# Altium schematic sheet [1] Peripherals.SchDoc("[1] Peripherals")
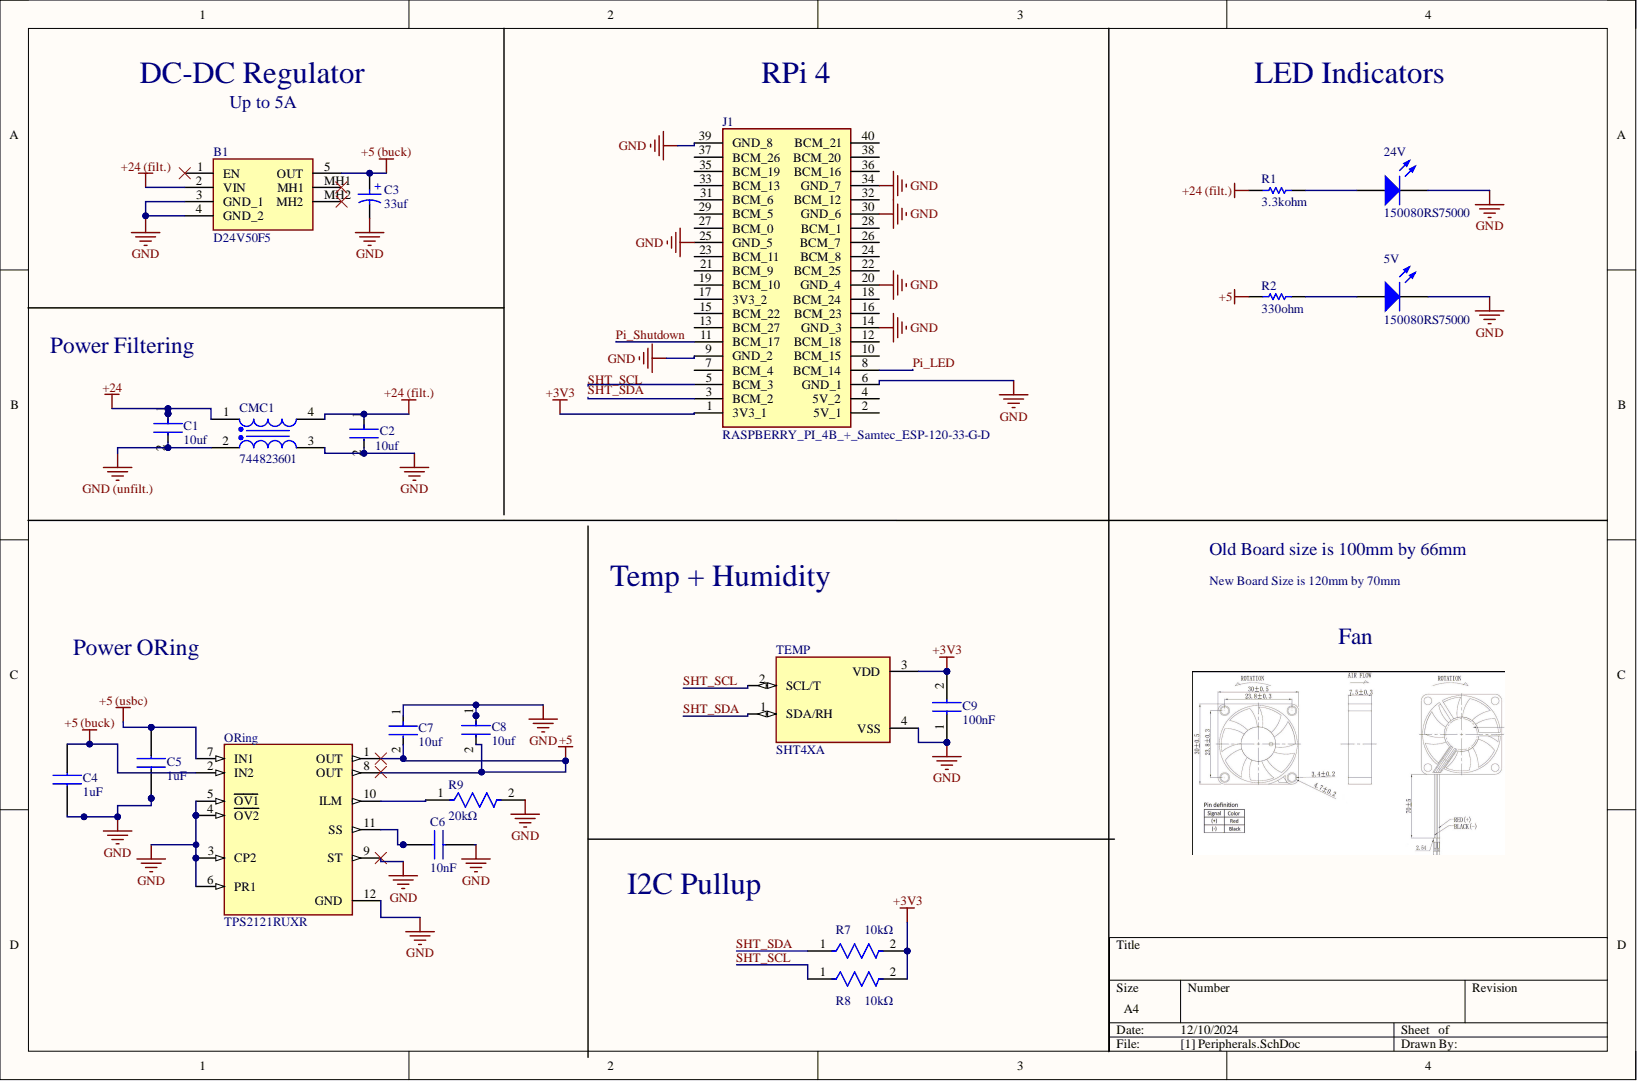
[diagram p0: [1] Peripherals.SchDoc]

## Parts
5V  150080RS75000
24V  150080RS75000
B1  D24V50F5
C1  10uf
C2  10uf
C3  33uf
C4  1uF
C5  1uF
C6  10nF
C7  10uf
C8  10uf
C9  100nF
CMC1  744823601
J1  RASPBERRY_PI_4B_+_Samtec_ESP-120-33-G-D
ORing  TPS2121RUXR
R1  3.3kohm
R2  330ohm
R7  10kΩ
R8  10kΩ
R9  20kΩ
TEMP  SHT4XA

## Nets
+3V3: C9.2 J1.1(3V3_1) R7.2 R8.2 TEMP.3(VDD)
+5: C7.2 C8.2 ORing.1(OUT) ORing.8(OUT) R2.1
+5 (buck): B1.5(OUT) C3.1 C4.2 ORing.2(IN2)
+5 (usbc): C5.2 ORing.7(IN1)
+24: C1.1 CMC1.1
+24 (filt.): B1.2(VIN) C2.1 CMC1.4 R1.1
GND: 5V.1 24V.1 B1.3(GND_1) B1.4(GND_2) C2.2 C3.2 C4.1 C5.1 C6.2 C7.1 C8.1 C9.1 CMC1.3 J1.6(GND_1) J1.9(GND_2) J1.14(GND_3) J1.20(GND_4) J1.25(GND_5) J1.30(GND_6) J1.34(GND_7) J1.39(GND_8) ORing.3(CP2) ORing.4(OV2) ORing.5(OV1) ORing.6(PR1) ORing.9(ST) ORing.12(GND) R9.2 TEMP.4(VSS)
GND (unfilt.): C1.2 CMC1.2
Pi_LED: J1.8(BCM_14)
Pi_Shutdown: J1.11(BCM_17)
SHT_SCL: J1.5(BCM_3) R8.1 TEMP.2(SCL/T)
SHT_SDA: J1.3(BCM_2) R7.1 TEMP.1(SDA/RH)
Net5V_2: 5V.2 R2.2
Net24V_2: 24V.2 R1.2
NetC6_1: C6.1 ORing.11(SS)
NetORing_10: ORing.10(ILM) R9.1

Not connected: B1 1(EN) MH1 MH2; J1 2(5V_1) 4(5V_2) 7(BCM_4) 10(BCM_15) 12(BCM_18) 13(BCM_27) 15(BCM_22) 16(BCM_23) 17(3V3_2) 18(BCM_24) 19(BCM_10) 21(BCM_9) 22(BCM_25) 23(BCM_11) 24(BCM_8) 26(BCM_7) 27(BCM_0) 28(BCM_1) 29(BCM_5) 31(BCM_6) 32(BCM_12) 33(BCM_13) 35(BCM_19) 36(BCM_16) 37(BCM_26) 38(BCM_20) 40(BCM_21)

## Library data of the parts
5V 24V = CMP-00047-1: LED Uni-Color Red 630nm 2-Pin Chip 0805(2012Metric) T/R; Dominant Wavelength: 625nm; Forward Current: 30mA; Forward Voltage: 2V; Lens Color: Colorless; Lens Size: 1.25mm; Lens Style: Clear,Flat,Rectangular; Lens Transparency: Clear; Luminous Intensity: 150mcd; Test Current: 20mA; Viewing Angle: 140°; Case Code (Imperial): 0805; Case Code (Metric): 2012; Case/Package: 0805; Color: Red; Component Kind: Standard; Height: 0.8mm; Length: 2mm; Max Operating Temperature: 85°C; Min Operating Temperature: -40°C; Mounting Technology: Surface Mount; Package Quantity: 1; Packaging: Cut Tape; Reach SVHC Compliant: Unknown; RoHS Compliant: Yes; Series: WL-SMCW; Wavelength: 625nm; Width: 1.25mm; Library Name: BumbleBee Autonomous Systems - NUS
B1 = CMP-082-000000-1: Pololu 5V, 5A Step-Down Voltage Regulator D24V50F5; Geometry.Height: 8.77mm; Component Kind: Standard; Datasheet Link: https://www.pololu.com/file/0J1436/d24v50f5-step-down-voltage-regulator-dimensions.pdf; Design Item ID: D24V50F5; Manufacturer_Name: Pololu; Manufacturer_Part_Number: D24V50F5; Source: D24V50F5.SchLib; Library Name: BumbleBee Autonomous Systems - NUS
C1 C2 C7 C8 = CMP-06035-005015-1: Chip Multilayer Ceramic Capacitors for General Purpose, 0805, 10uF, X5R, 15%, 10%, 50V; Component Kind: Standard; Library Name: Altium Content Vault
C3 = CMP-03913-130590178-1: 865060857004 Cap, 33Uf, 20%, 100V, Alu Elec Smd Rohs Compliant: Yes; Capacitance: 33µF; Impedance: 1.25Ω; Insulation Resistance: 3.0303MΩ; Leakage Current: 33µA; Ripple Current: 200mA; Component Kind: Standard; Diameter: 10mm; Length: 10.5mm; Library Name: Altium Content Vault; NexarPartId: 130590178; Voltage Rating: 100V; Width: 10.3mm
C4 C5 = CMP-048-000000-2: Cap Ceramic 1uF 50V X7R 5% SMD 0805 125C Embossed T/R; Manufacturer_Name: AVX; Manufacturer_Part_Number: 08055C105JAT2A; Geometry.Height: 1.4mm; Component Kind: Standard; Datasheet Link: https://componentsearchengine.com/Datasheets/1/08055C105JAT2A.pdf; Design Item ID: 08055C105JAT2A; Mouser Part Number: 581-08055C105JAT2A; Mouser Price/Stock: https://www.mouser.com/Search/Refine.aspx?Keyword=581-08055C105JAT2A; Source: 08055C105JAT2A.SchLib; Library Name: BumbleBee Autonomous Systems - NUS
C6 = CMP-012-000000-2: 885382207006 Multilayer Ceramic Capacitors MLCC - SMD/SMT WCAP-CSST 0805 10nF 10% 50VDC; Manufacturer_Name: Wurth Elektronik; Geometry.Height: 1.35mm; Component Kind: Standard; Datasheet Link: https://katalog.we-online.com/pbs/datasheet/885382207006.pdf; Design Item ID: 885382207006; Manufacturer_Part_Number: 885382207006; Mouser Part Number: 710-885382207006; Mouser Price/Stock: https://www.mouser.co.uk/ProductDetail/Wurth-Elektronik/885382207006?qs=0lSvoLzn4L%252BUsoUl2i%252Bhaw%3D%3D; Source: 885382207006.SchLib; Library Name: BumbleBee Autonomous Systems - NUS
C9 = CMP-061-000001-1: Capacitor 885012207098R; Manufacturer_Name: Wurth Elektronik; Component Kind: Standard; Datasheet Link: https://www.we-online.com/en/components/products/WCAP-CSGP-50VDC#885012207098R; Design Item ID: 885012207098R; Height: 0.9mm; Manufacturer_Part_Number: 885012207098R; Source: SamacSys.SchLib; Library Name: BumbleBee Autonomous Systems - NUS
CMC1 = CMP-0223-00094-2: NexarPartId: 22803426; Current Rating: 6A; Inductance: 1mH; Inductance Tolerance: 30%; Pin Length: 3mm; Resistance: 13mΩ; Voltage Rating: 250V; Ambient Temperature Range High: 70°C; Ambient Temperature Range Low: -40°C; Component Kind: Standard; Height: 26.5mm; Length: 25mm; Library Name: Altium Content Vault; Max Operating Temperature: 125°C; Min Operating Temperature: -40°C; Number of Pins: 4; Packaging: Bulk; RoHS: Compliant; Width: 17mm
J1 = CMP-062-000003-1: RASPBERRY PI 4 MODEL B with Samtec ESP-120-33-G-D connector drawn from underneath for use as a plug in module.; Manufacturer_Name: RASPBERRY-PI; Manufacturer_Part_Number: RASPBERRY PI 4B + Samtec ESP-120-33-G-D; Component Kind: Standard; Datasheet Link: https://www.raspberrypi.org/documentation/hardware/raspberrypi/mechanical/rpi_MECH_4b_4p0.pdf; Design Item ID: RASPBERRY_PI_4B_+_Samtec_ESP-120-33-G-D; Height: 24.3mm; Source: SamacSys.SchLib; Library Name: BumbleBee Autonomous Systems - NUS
ORing = CMP-04910-000009-1: PWR MGMT BATTERY MGMT; Manufacturer: Texas Instruments; Max Junction Temp: +125°C; Max Supply Voltage: 22V; Min Supply Voltage: 2.8V; Nominal Supply Current: 300uA; Number Of Outputs: 1; Output Current: 1.5-5.2A; Standoff Height: 0mm; Automotive: No; Category: IC; Component Kind: Standard; ComponentLink2Description: Datasheet; ComponentLink2URL: https://www.ti.com/lit/ds/symlink/tps2121.pdf; Device Class L1: Integrated Circuits (ICs); Device Class L2: Power Management ICs; Device Class L3: unset; Digikey Description: PWR MGMT BATTERY MGMT; Height: 1mm; Lead Free: Yes; Library Name: Altium Content Vault; Manufacturer Part Number: TPS2121RUXR; Mouser Description: 2.7-22V, 56m?, 4.5A, Priority Power MUX with Seamless Switchover 12-VQFN-HR -40 to 125; Octopart: https://octopart.com/tps2121ruxr-texas+instruments-95511053; Output Voltage: 22V; Package: RUX0012A; RoHS: TRUE; Temperature: -40°C to +125°C; Temperature Range High: +125°C; Temperature Range Low: -40°C
R1 = CMP-1013-00567-2: Chip Resistor, 3.3 KOhm, +/- 1%, 0.125 W, -55 to 155 degC, 0805 (2012 Metric), RoHS, Tape and Reel; Manufacturer: Yageo; Max Power Dissipation: 125mW; Temperature Coefficient: 100ppm/degreesC; Voltage Rating: 150V; Case Code (Imperial): 0805; Case Code (Metric): 2012; Case/Package: 0805; Component Kind: Standard; Composition: ThickFilm; Datasheet URL: http://www.yageo.com/NewPortal/yageodocoutput?fileName=/pdf/R-Chip/PYu-RC_Group_51_RoHS_L_5.pdf; Datasheet Version: Rev. 5, 10/2016; Depth: 1.25mm; Height: 0.5mm; Lead Free: LeadFree; Length: 2mm; Library Name: Altium Content Vault; Manufacturer URL: http://www.yageo.com/; Max Operating Temperature: 155degC; Min Operating Temperature: -55degC; Number of Terminations: 2; Package Description: 2-Pin Surface Mounted Device, Body 2 x 1.25 mm; Package Quantity: 1; Package Reference: 0805; Packaging: TapeandReel; Power Rating: 125mW; REACH SVHC: NoSVHC; Resistance: 3.3KOhm; RoHSCompliant: true; Termination: SMD/SMT; Tolerance: 1%; Weight: 0.000194oz; Width: 0.049inch
R2 = CMP-2001-00628-1: Manufacturer: Panasonic; Part Number: ERA6AEB331V; Component Kind: Standard; Library Name: Altium Content Vault
R7 R8 = CMP-00003-1: RT0805FRE0710KL Res Thin Film 0805 10K Ohm 1% 0.125W(1/8W) ±50ppm/°C Pad SMD T/R; Max Power Dissipation: 125mW; Value: 10kR; Voltage Rating: 150V; Case Code (Imperial): 0805; Case Code (Metric): 2012; Case/Package: 0805; Component Kind: Standard; Datasheet: https://www.mouser.sg/datasheet/2/447/PYu_RT_1_to_0_01_RoHS_L_12-3003070.pdf; Height: 0.024inch; Lead Free: Lead Free; Length: 0.079inch; Max Operating Temperature: 125°C; Min Operating Temperature: -55°C; Number of Terminations: 2; Package Quantity: 5000; Packaging: Tape and Reel; Power Rating: 125mW; Reach SVHC Compliant: No; Resistance Tolerance: 1%; Resistor Type: Thin Film; RoHS Compliant: Yes; Series: RT; Technology: THIN FILM; Temperature Coefficient: 50ppm/°C; Termination: SMD/SMT; Tolerance: 1%; Width: 1.25mm; Working Voltage: 150V; Library Name: BumbleBee Autonomous Systems - NUS
R9 = CMP-147-000032-2: Fixed Resistor, Metal Glaze/thick Film, 0.125W, 20000ohm, 150V, 5% +/-Tol, 200ppm/Cel, Surface Mount, 0805; Manufacturer_Name: Vishay; Manufacturer_Part_Number: CRCW080520K0JNEA; Temperature Coefficient: 200ppm/°C; Value: 20kR; Case/Package: 0805; Component Kind: Standard; Max Operating Temperature: 155°C; Min Operating Temperature: -55°C; Tolerance: 5%; Library Name: BumbleBee Autonomous Systems - NUS
TEMP = CMP-163-000000-1: Automotive Grade Relative Humidity Temperature Sensor, Â±3 %RH, Â±0.3 Â°C; MF: Sensirion; MP: SHT40A-AD1B-R3; Availability: Not in stock; Check_prices: https://www.snapeda.com/parts/SHT40A-AD1B-R3/Sensirion/view-part/?ref=eda; Component Kind: Standard; Component Type: Standard; Design Item ID: SHT4XA; Package: DFN-4 Sensirion; Pin Count: 4; Price: None; SnapEDA_Link: https://www.snapeda.com/parts/SHT40A-AD1B-R3/Sensirion/view-part/?ref=snap; Source: SHT4XA.SchLib; Library Name: BumbleBee Autonomous Systems - NUS
